# Altium schematic sheet B14_B16.SchDoc(B14_B16)
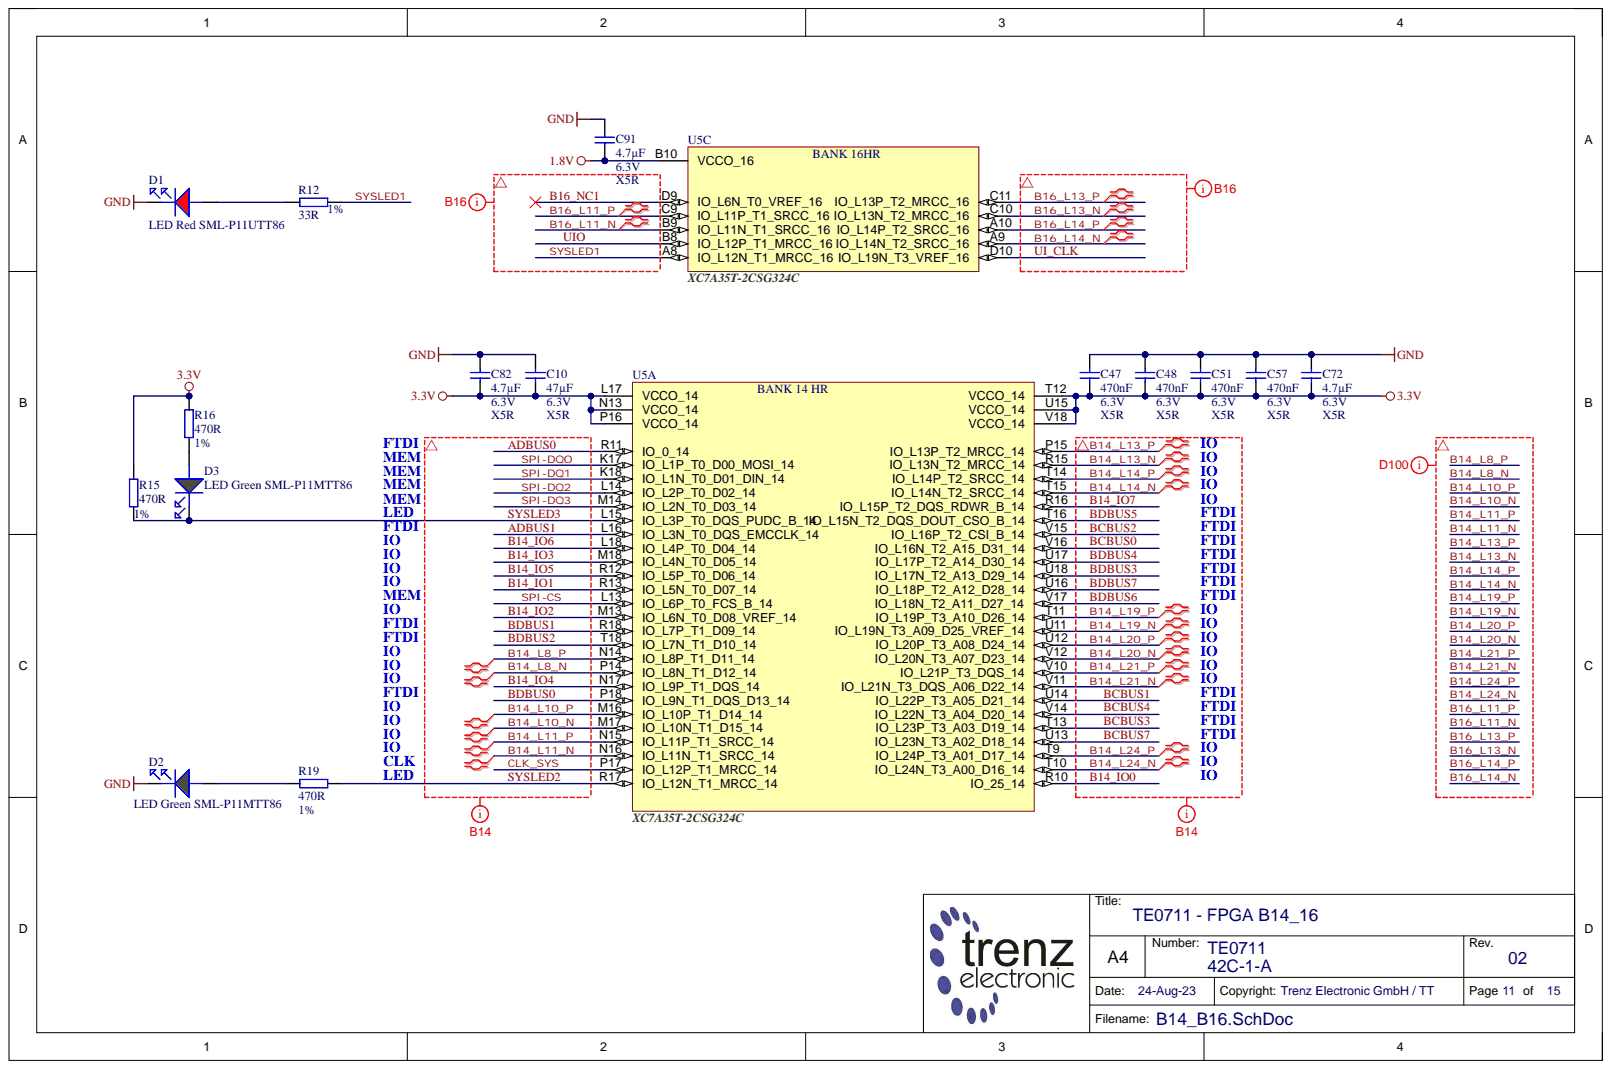
[diagram p0: B14_B16.SchDoc]

## Parts
C10  47µF  Technology: X5R  Voltage: 6.3V  [CAPC2012X135N_V]
C47  470nF  Technology: X5R  Voltage: 6.3V  [CAPC0603X33N_V]
C48  470nF  Technology: X5R  Voltage: 6.3V  [CAPC0603X33N_V]
C51  470nF  Technology: X5R  Voltage: 6.3V  [CAPC0603X33N_V]
C57  470nF  Technology: X5R  Voltage: 6.3V  [CAPC0603X33N_V]
C72  4.7µF  Technology: X5R  Voltage: 6.3V  [CAPC1005X55N_V]
C82  4.7µF  Technology: X5R  Voltage: 6.3V  [CAPC1005X55N_V]
C91  4.7µF  Technology: X5R  Voltage: 6.3V  [CAPC1005X55N_V]
D1  LED Red SML-P11UTT86  Database Table Name: LED  Extra: SML-P11UTT86  [LEDC1006X25N_RED]
D2  LED Green SML-P11MTT86  Database Table Name: LED  Extra: SML-P11MTT86  [LEDC1006X25N_GREEN]
D3  LED Green SML-P11MTT86  Database Table Name: LED  [LEDC1006X25N_GREEN]
R12  33R  Tolerance: 1%  [RESC1005X40N_V]
R15  470R  Tolerance: 1%  [RESC1005X40N_V]
R16  470R  Tolerance: 1%  [RESC1005X40N_V]
R19  470R  Tolerance: 1%  [RESC1005X40N_V]
U5  XC7A35T-2CSG324C  [BGA324C80P18X18_1500X1500X150N]  (parts A,C)
U5
U5
U5
U5
U5
U5

## Nets
1.8V: C91.2 U5.B10
3.3V: C10.2 C47.2 C48.2 C51.2 C57.2 C72.2 C82.2 R15.2 R16.2 U5.L17 U5.N13 U5.P16 U5.T12 U5.U15 U5.V18
GND: C10.1 C47.1 C48.1 C51.1 C57.1 C72.1 C82.1 C91.1 D1.K D2.K
ADBUS0: U5.R11
ADBUS1: U5.L16
B14_IO0: U5.R10
B14_IO1: U5.R13
B14_IO2: U5.M13
B14_IO3: U5.M18
B14_IO4: U5.N17
B14_IO5: U5.R12
B14_IO6: U5.L18
B14_IO7: U5.R16
B14_L8_N: U5.P14
B14_L8_P: U5.N14
B14_L10_N: U5.M17
B14_L10_P: U5.M16
B14_L11_N: U5.N16
B14_L11_P: U5.N15
B14_L13_N: U5.R15
B14_L13_P: U5.P15
B14_L14_N: U5.T15
B14_L14_P: U5.T14
B14_L19_N: U5.U11
B14_L19_P: U5.T11
B14_L20_N: U5.V12
B14_L20_P: U5.U12
B14_L21_N: U5.V11
B14_L21_P: U5.V10
B14_L24_N: U5.T10
B14_L24_P: U5.T9
B16_L11_N: U5.B9
B16_L11_P: U5.C9
B16_L13_N: U5.C10
B16_L13_P: U5.C11
B16_L14_N: U5.A9
B16_L14_P: U5.A10
B16_NC1: U5.D9
BCBUS0: U5.V16
BCBUS1: U5.U14
BCBUS2: U5.V15
BCBUS3: U5.T13
BCBUS4: U5.V14
BCBUS7: U5.U13
BDBUS0: U5.P18
BDBUS1: U5.R18
BDBUS2: U5.T18
BDBUS3: U5.U18
BDBUS4: U5.U17
BDBUS5: U5.T16
BDBUS6: U5.V17
BDBUS7: U5.U16
CLK_SYS: U5.P17
SPI-CS: U5.L13
SPI-DQ1: U5.K18
SPI-DQ2: U5.L14
SPI-DQ3: U5.M14
SPI-DQO: U5.K17
SYSLED1: R12.2 U5.A8
SYSLED2: R19.1 U5.R17
SYSLED3: D3.K R15.1 U5.L15
UI_CLK: U5.D10
UIO: U5.B8
NetD1_A: D1.A R12.1
NetD2_A: D2.A R19.2
NetD3_A: D3.A R16.1

## Library data of the parts
C10 = 24718: KON 47µF 6,3V X5R 20% SMD BF 2012 (0805); Database Table Name: C_2012_0805; H-ART: CC0805MKX5R5BB476; Mixed: SMT; Preferred: Yes; Temperature-Range: -55/+85; Value: 47u; AN: 24718; Case: 2012 (0805); Component Kind: Standard; Library Name: TE.DbLib; Tolerance: 20%
C47 C48 C51 C57 = 24921: KON 470nF 6.3V X5R 20%  SMD BF 0603 (0201); Database Table Name: C_0603_0201; Mixed: SMT; Preferred: Yes; Temperature-Range: -55/+85; Value: 470n; AN: 24921; Case: 0603 (0201); Component Kind: Standard; Library Name: TE.DbLib; Tolerance: 20%
C72 C82 C91 = 24719: KON 4.7µF 6.3V X5R 20% SMD BF 1005 (0402); Database Table Name: C_1005_0402; Mixed: SMT; Preferred: Yes; Temperature-Range: -55/+85; Value: 4.7u; AN: 24719; Case: 1005 (0402); Component Kind: Standard; Library Name: TE.DbLib; Tolerance: 20%
D1 = 24212: Chip LED 0402 Red; H-ART: SML-P11UTT86R; Mixed: SMT; Preferred: Yes; Temperature-Range: -40/+85 (Abs); AN: 24212; Case: 1005 (0402); Component Kind: Standard; Library Name: TE.DbLib; Storage-Temperature: -40/+100 (Abs); HelpURL: B:\AD\adlib\datasheet\SML-P1
D2 D3 = 24211: Chip LED 0402 Green; H-ART: SML-P11MTT86R; Mixed: SMT; Preferred: Yes; Temperature-Range: -40/+85; AN: 24211; Case: 1005 (0402); Component Kind: Standard; Library Name: TE.DbLib; HelpURL: B:\AD\adlib\datasheet\SML-P1
  D3: Extra: SML-P11MTT86
R12 = 22770: WID SMD 33R 1% 0,063W BF 1005 (0402); Database Table Name: R_1005_0402; H-ART: RC0402FR-0733RL; Mixed: SMT; Preferred: Yes; Value: 33; Voltage: 0.063W; AN: 22770; Case: 1005 (0402); Component Kind: Standard; Library Name: TE.DbLib; Technology: TK100
R15 R16 R19 = 22769: WID SMD 470R 1% 0,063W BF 1005 (0402); Database Table Name: R_1005_0402; Mixed: SMT; Preferred: Yes; Value: 470; Voltage: 0.063W; AN: 22769; Case: 1005 (0402); Component Kind: Standard; Library Name: TE.DbLib; Technology: TK100
U5 = 24908: AN: 25960; Database Table Name: PROG_LOGIC; Extra: Xilinx Artix-7 FPGA 100K; H-ART: XC7A100T-2CSG324C; Mixed: SMT; Trenz-Stock: Yes; Case: CSG324; Component Kind: Standard; Description: XC7A35T-2CSG324C; Library Name: TE.DbLib; HelpURL: B:\AD\adlib\datasheet\XC7
